# Altium schematic sheet [08] PCIe.SchDoc("[08] PCIe")
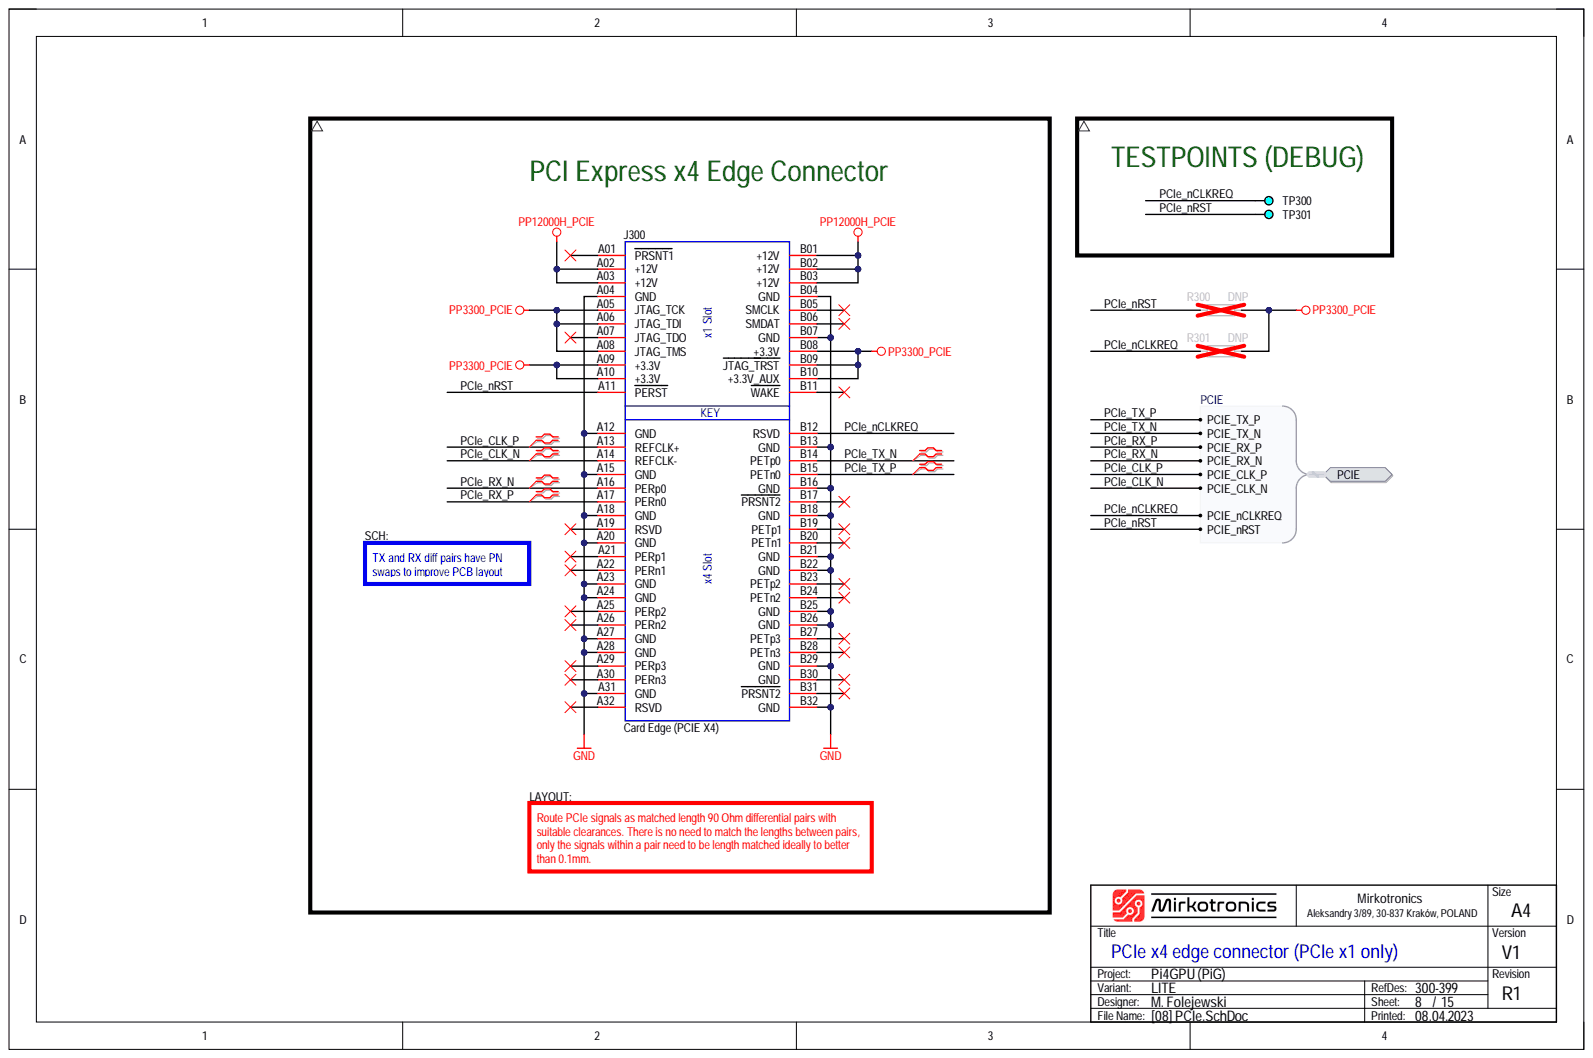
[diagram p0: [08] PCIe.SchDoc]

## Parts
J300  Card Edge (PCIE X4)  [PCB_CARD_EDGE_PCIE_64P]  (no BOM)
R300  DNP  [RES_0603_R]
R301  DNP  [RES_0603_R]
TP300  DEBUG  [PCB_TP_DBG_50]  (no BOM)
TP301  DEBUG  [PCB_TP_DBG_50]  (value not drawn)  (no BOM)

## Ports
PCIE, PCIE.PCIE_CLK_N, PCIE.PCIE_CLK_P, PCIE.PCIE_nCLKREQ, PCIE.PCIE_nRST, PCIE.PCIE_RX_N, PCIE.PCIE_RX_P, PCIE.PCIE_TX_N, PCIE.PCIE_TX_P

## Nets
GND: J300.A04(GND) J300.A12(GND) J300.A15(GND) J300.A18(GND) J300.A20(GND) J300.A23(GND) J300.A24(GND) J300.A27(GND) J300.A28(GND) J300.A31(GND) J300.B04(GND) J300.B07(GND) J300.B13(GND) J300.B16(GND) J300.B18(GND) J300.B21(GND) J300.B22(GND) J300.B25(GND) J300.B26(GND) J300.B29(GND) J300.B32(GND)
PP3300_PCIE: J300.A05(JTAG_TCK) J300.A06(JTAG_TDI) J300.A08(JTAG_TMS) J300.A09(+3.3V) J300.A10(+3.3V) J300.B08(+3.3V) J300.B09(JTAG_TRST) J300.B10(+3.3V_AUX) R300.1 R301.1
PP12000H_PCIE: J300.A02(+12V) J300.A03(+12V) J300.B01(+12V) J300.B02(+12V) J300.B03(+12V)
PCIe_CLK_N: J300.A14(REFCLK-) ; port PCIE
PCIe_CLK_P: J300.A13(REFCLK+) ; port PCIE
PCIe_nCLKREQ: J300.B12(RSVD) R301.2 TP300.1 ; port PCIE
PCIe_nRST: J300.A11(PERST) R300.2 TP301.1 ; port PCIE
PCIe_RX_N: J300.A16(PERp0) ; port PCIE
PCIe_RX_P: J300.A17(PERn0) ; port PCIE
PCIe_TX_N: J300.B14(PETp0) ; port PCIE
PCIe_TX_P: J300.B15(PETn0) ; port PCIE

Not connected: J300 A01(PRSNT1) A07(JTAG_TDO) A19(RSVD) A21(PERp1) A22(PERn1) A25(PERp2) A26(PERn2) A29(PERp3) A30(PERn3) A32(RSVD) B05(SMCLK) B06(SMDAT) B11(WAKE) B17(PRSNT2) B19(PETp1) B20(PETn1) B23(PETp2) B24(PETn2) B27(PETp3) B28(PETn3) B30(GND) B31(PRSNT2)

## Library data of the parts
J300 = CON_PCIE_64P_VAR2: Connector; PCIE, x4 (64pin); Library Name: Adapter_X4_Lib.SchLib; Manufacturer: N/A; MPN: N/A; Value: Card Edge (PCIE X4)
R300 R301 = RES-0054: Resistor; Thick Film; GENERIC; TBD; TBD; TBD; TBD; TBD; SMD; 0603 (1608);; Database Table Name: RESISTOR$; Component Kind: Standard; Component Subtype: Thick Film; Component Type: Resistor; Manufacturer: GENERIC; Mounting Type: SMD; MPN: GENERIC; Package (Case): 0603 (1608); Supplier: ?; Value: DNP; Library Name: MirFol_db_local.DbLib
TP300 TP301 = PCB_TP_DBG: PCB Marking; Test Point (Debug);; Component Subtype: -; Component Type: Testpoint; Mounting Type: -; Supplier: ?; Value: DEBUG; Library Name: MirFol_lib.SchLib
